# Altium schematic sheet karadio.mb.SchDoc
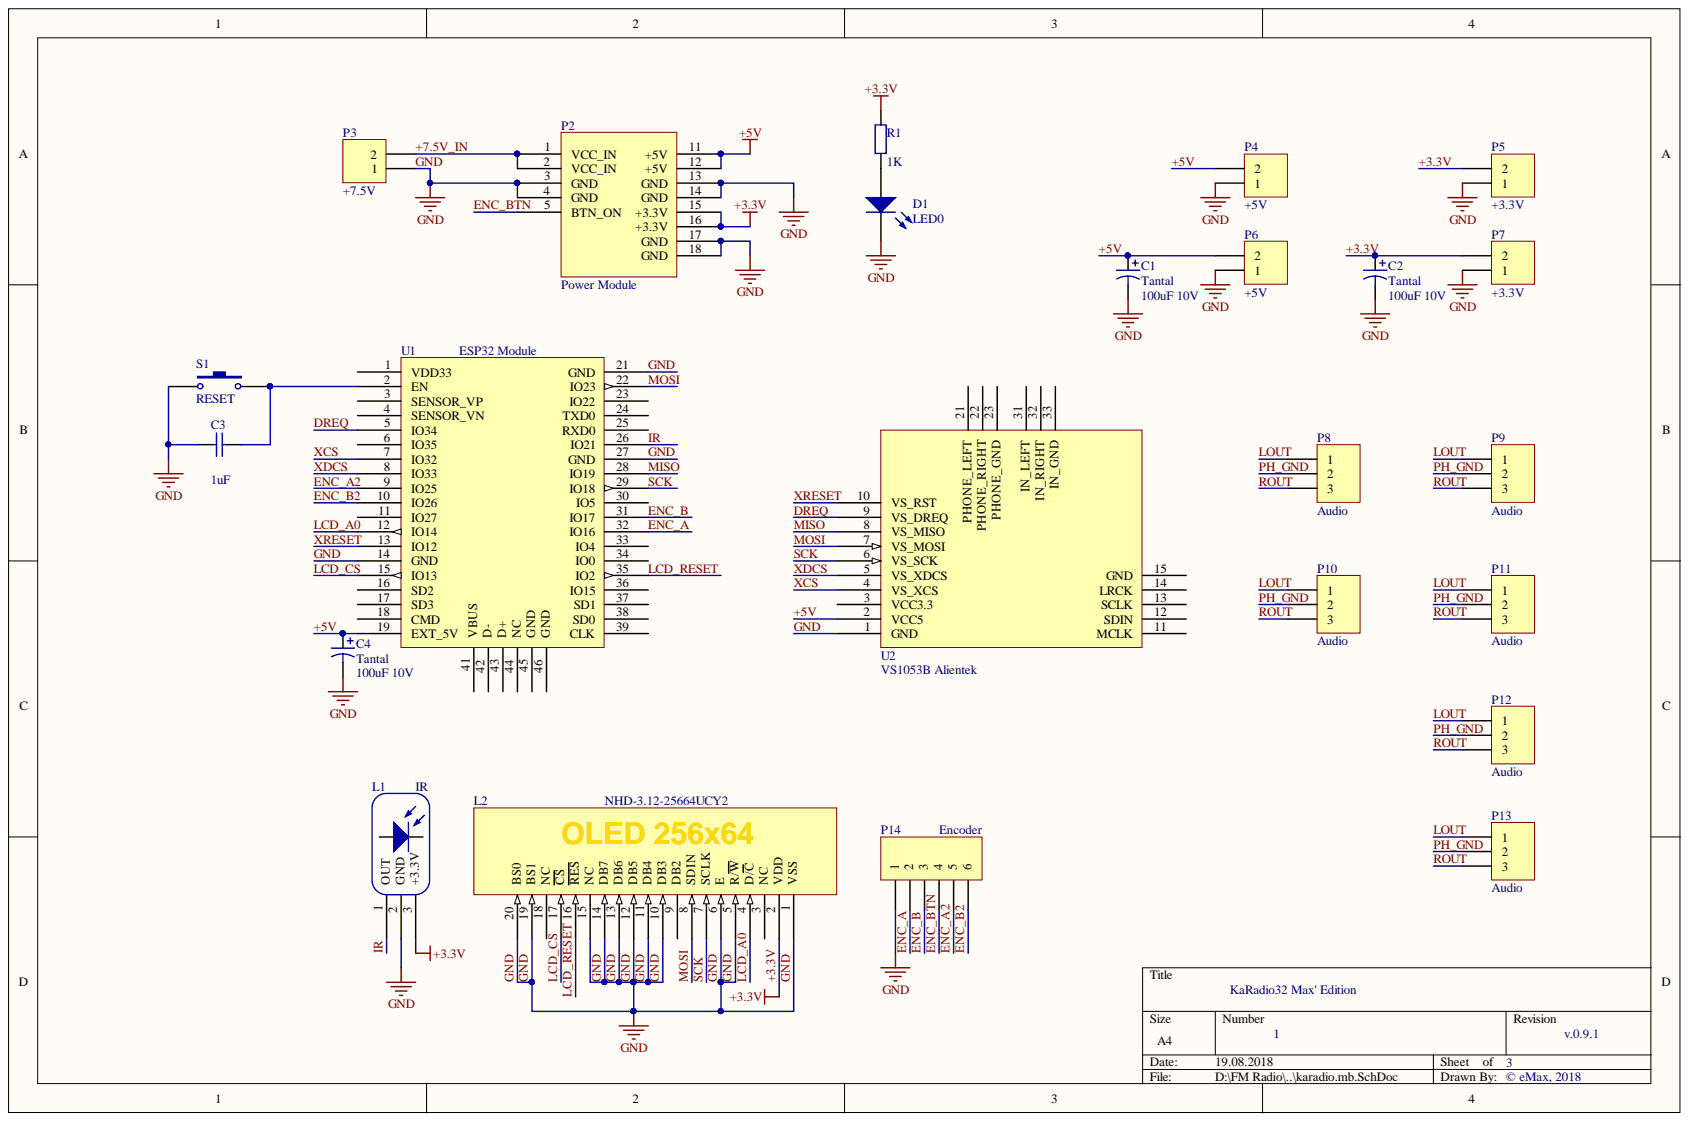
[diagram p0: karadio.mb.SchDoc]

## Parts
C1  Tantal  value 100uF 10V  [TANTAL_B]
C2  Tantal  value 100uF 10V  [TANTAL_B]
C3  (no value)  [0805]
C4  Tantal  value 100uF 10V  [TANTAL_B]
D1  LED0  [HDR1X2]
L1  IR  [HDR1X3]
L2  NHD-3.12-25664UCY2  [HDR1X20]
P2  Power Module  [POWER_MODULE]
P3  +7.5V  [HDR_PWR_2]
P4  +5V  [HDR_PWR_2]
P5  +3.3V  [HDR_PWR_2]
P6  +5V  [HDR_PWR_2]
P7  +3.3V  [HDR_PWR_2]
P8  Audio  [HDR1X3]
P9  Audio  [HDR1X3]
P10  Audio  [HDR1X3]
P11  Audio  [HDR1X3]
P12  Audio  [HDR1X3]
P13  Audio  [HDR1X3]
P14  Encoder  [HDR1X6]
R1  value 1K  [0805]
S1  RESET  [HDR1X2]
U1  ESP32 Module  [ESP32_MODULE]
U2  VS1053B Alientek  [VS1053B_MODULE]

## Nets
+3.3V: C2.1 L1.3 L2.2(VDD) P2.15(+3.3V) P2.16(+3.3V) P5.2 P7.2 R1.2
+5V: C1.1 C4.1 P2.11(+5V) P2.12(+5V) P4.2 P6.2 U1.19(EXT_5V) U2.2(VCC5)
+7.5V_IN: P2.1(VCC_IN) P2.2(VCC_IN) P3.2
DREQ: U1.5(IO34) U2.9(VS_DREQ)
ENC_A: P14.2 U1.32(IO16)
ENC_A2: P14.5 U1.9(IO25)
ENC_B: P14.3 U1.31(IO17)
ENC_B2: P14.6 U1.10(IO26)
ENC_BTN: P2.5(BTN_ON) P14.4
GND: C1.2 C2.2 C3.2 C4.2 D1.2 L1.2 L2.1(VSS) L2.5(R/W) L2.6(E) L2.10(DB3) L2.11(DB4) L2.12(DB5) L2.13(DB6) L2.14(DB7) L2.15(NC) L2.19(BS1) L2.20(BS0) P2.3(GND) P2.4(GND) P2.13(GND) P2.14(GND) P2.17(GND) P2.18(GND) P3.1 P4.1 P5.1 P6.1 P7.1 P14.1 S1.1 U1.14(GND) U1.21(GND) U1.27(GND) U2.1(GND)
IR: L1.1 U1.26(IO21)
LCD_A0: L2.4(D/C) U1.12(IO14)
LCD_CS: L2.17(CS) U1.15(IO13)
LCD_RESET: L2.16(RES) U1.35(IO2)
LOUT: P8.1 P9.1 P10.1 P11.1 P12.1 P13.1
MISO: U1.28(IO19) U2.8(VS_MISO)
MOSI: L2.8(SDIN) U1.22(IO23) U2.7(VS_MOSI)
PH_GND: P8.2 P9.2 P10.2 P11.2 P12.2 P13.2
ROUT: P8.3 P9.3 P10.3 P11.3 P12.3 P13.3
SCK: L2.7(SCLK) U1.29(IO18) U2.6(VS_SCK)
XCS: U1.7(IO32) U2.4(VS_XCS)
XDCS: U1.8(IO33) U2.5(VS_XDCS)
XRESET: U1.13(IO12) U2.10(VS_RST)
NetC3_1: C3.1 S1.2 U1.2(EN)
NetD1_1: D1.1 R1.1

Not connected: L2 3(NC) 9(DB2) 18(NC); U1 1(VDD33) 3(SENSOR_VP) 4(SENSOR_VN) 6(IO35) 11(IO27) 16(SD2) 17(SD3) 18(CMD) 23(IO22) 24(TXD0) 25(RXD0) 30(IO5) 33(IO4) 34(IO0) 36(IO15) 37(SD1) 38(SD0) 39(CLK) 41(VBUS) 42(D-) 43(D+) 44(NC) 45(GND) 46(GND); U2 3(VCC3.3) 11(MCLK) 12(SDIN) 13(SCLK) 14(LRCK) 15(GND) 21(PHONE_LEFT) 22(PHONE_RIGHT) 23(PHONE_GND) 31(IN_LEFT) 32(IN_RIGHT) 33(IN_GND)

## Library data of the parts
C1 C2 C4 = Cap Pol1: Polarized Capacitor (Radial); Value: 100uF 10V; Component Kind: Standard; LatestRevisionDate: 17-Jul-2002; LatestRevisionNote: Re-released for DXP Platform.; Library Name: Miscellaneous Devices.IntLib; PackageDescription: Polarized Capacitor (Radial); 2 Leads; PackageReference: RB7.6-15; Published: 8-Jun-2000; Signal Integrity: Cap Pol; Simulation: CAP; Publisher: Altium Limited
C3 = Cap Semi: Capacitor (Semiconductor SIM Model); : 1uF; Component Kind: Standard; LatestRevisionDate: 17-Jul-2002; LatestRevisionNote: Re-released for DXP Platform.; Library Name: Miscellaneous Devices.IntLib; PackageReference: C1206; Published: 8-Jun-2000; Signal Integrity: Cap Semi; Simulation: CAP; Publisher: Altium Limited
D1 = LED0: Typical INFRARED GaAs LED; Component Kind: Standard; LatestRevisionDate: 08-Jul-2005; LatestRevisionNote: Mech Layer 1 removed.; Library Name: Miscellaneous Devices.IntLib; PackageDescription: LED; 2 Leads; PackageReference: LED-0; Published: 8-Jun-2000; Simulation: LED0; Publisher: Altium Limited
L1 = IR: IR Receiver; Component Kind: Standard; LatestRevisionDate: 08-Jul-2005; LatestRevisionNote: Mech Layer 1 removed.; PackageDescription: LED; 2 Leads; PackageReference: LED-0; Published: 8-Jun-2000; Library Name: karadio.SchLib; Publisher: Altium Limited
L2 = OLED256x64: Component Kind: Standard; Library Name: karadio.SchLib
P2 = POWER-MODULE: Power Module +5V +3.3V; Component Kind: Standard; Library Name: karadio.SchLib
P3-P7 = Header 2: Header, 2-Pin; Component Kind: Standard; LatestRevisionDate: 17-Jul-2002; LatestRevisionNote: Re-released for DXP Platform.; Library Name: Miscellaneous Connectors.IntLib; Signal Integrity: Connector; Publisher: Altium Limited
P8-P13 = Header 3: Header, 3-Pin; Component Kind: Standard; LatestRevisionDate: 17-Jul-2002; LatestRevisionNote: Re-released for DXP Platform.; Library Name: Miscellaneous Connectors.IntLib; Signal Integrity: Connector; Publisher: Altium Limited
P14 = Header 6: Header, 6-Pin; Component Kind: Standard; LatestRevisionDate: 17-Jul-2002; LatestRevisionNote: Re-released for DXP Platform.; Library Name: Miscellaneous Connectors.IntLib; Signal Integrity: Connector; Publisher: Altium Limited
R1 = Res2: Resistor; Component Kind: Standard; LatestRevisionDate: 17-Jul-2002; LatestRevisionNote: Re-released for DXP Platform.; Library Name: Miscellaneous Devices.IntLib; PackageReference: AXIAL-0.4; Published: 8-Jun-2000; Signal Integrity: Res; Simulation: RESISTOR; Publisher: Altium Limited
S1 = SW-PB: Switch; Component Kind: Standard; LatestRevisionDate: 17-Jul-2002; LatestRevisionNote: Re-released for DXP Platform.; Library Name: Miscellaneous Devices.IntLib; PackageDescription: Switch; 2 Leads; PackageReference: SPST-2; Published: 24-Mar-1999; Publisher: Altium Limited
U1 = ESP32: Component Kind: Standard; Library Name: karadio.SchLib
U2 = VS1053B: Component Kind: Standard; Library Name: karadio.SchLib
